# Altium schematic sheet pd.SchDoc(pd)
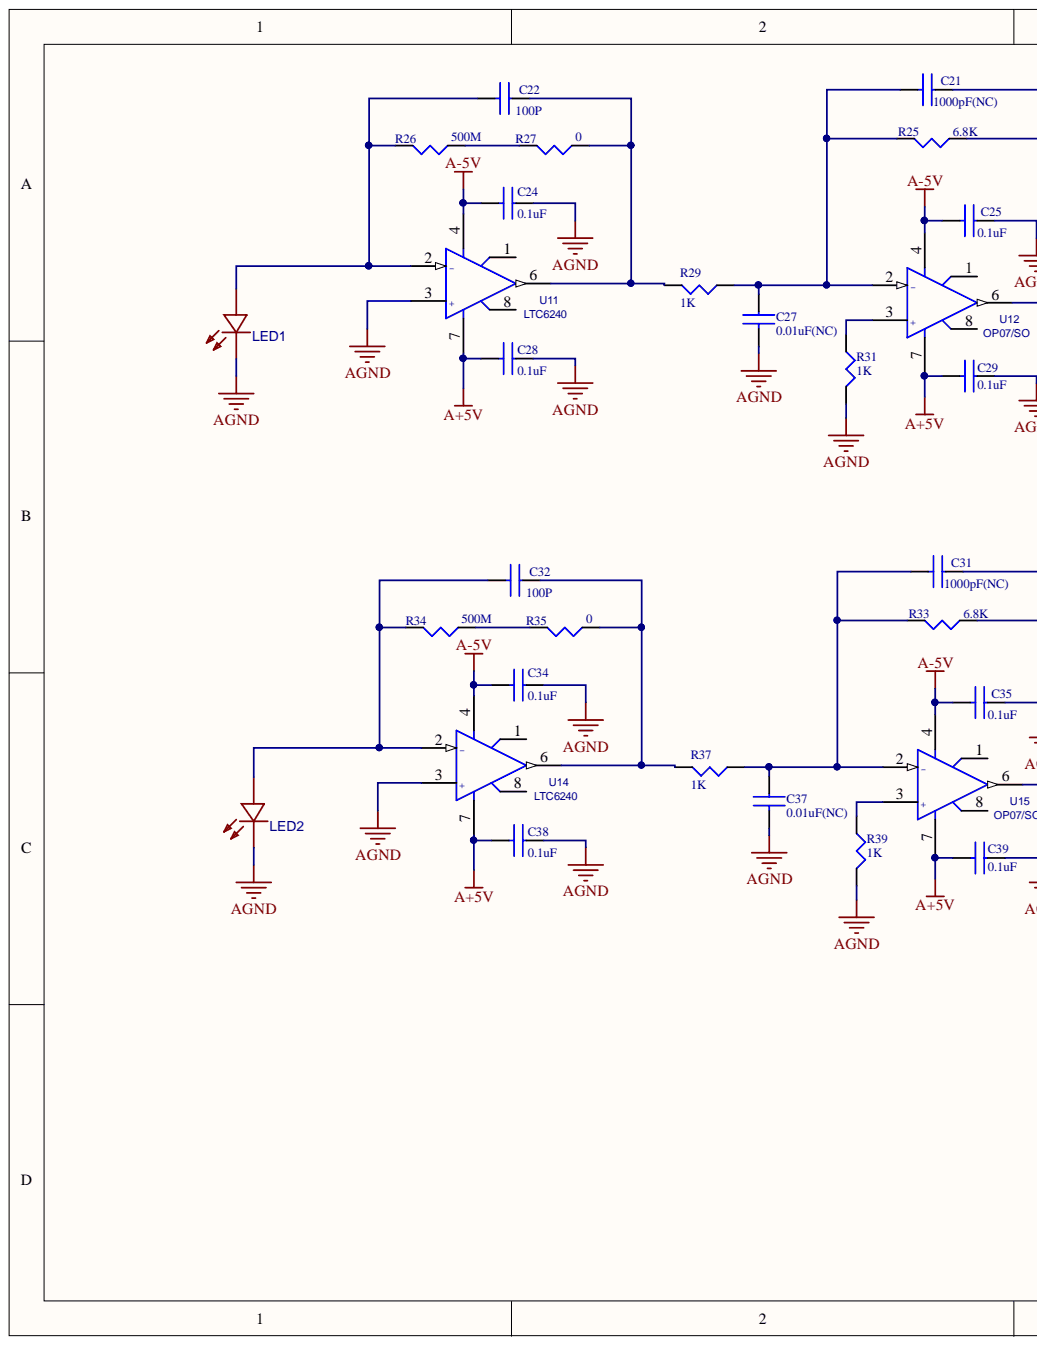
[diagram p0: pd.SchDoc, left]
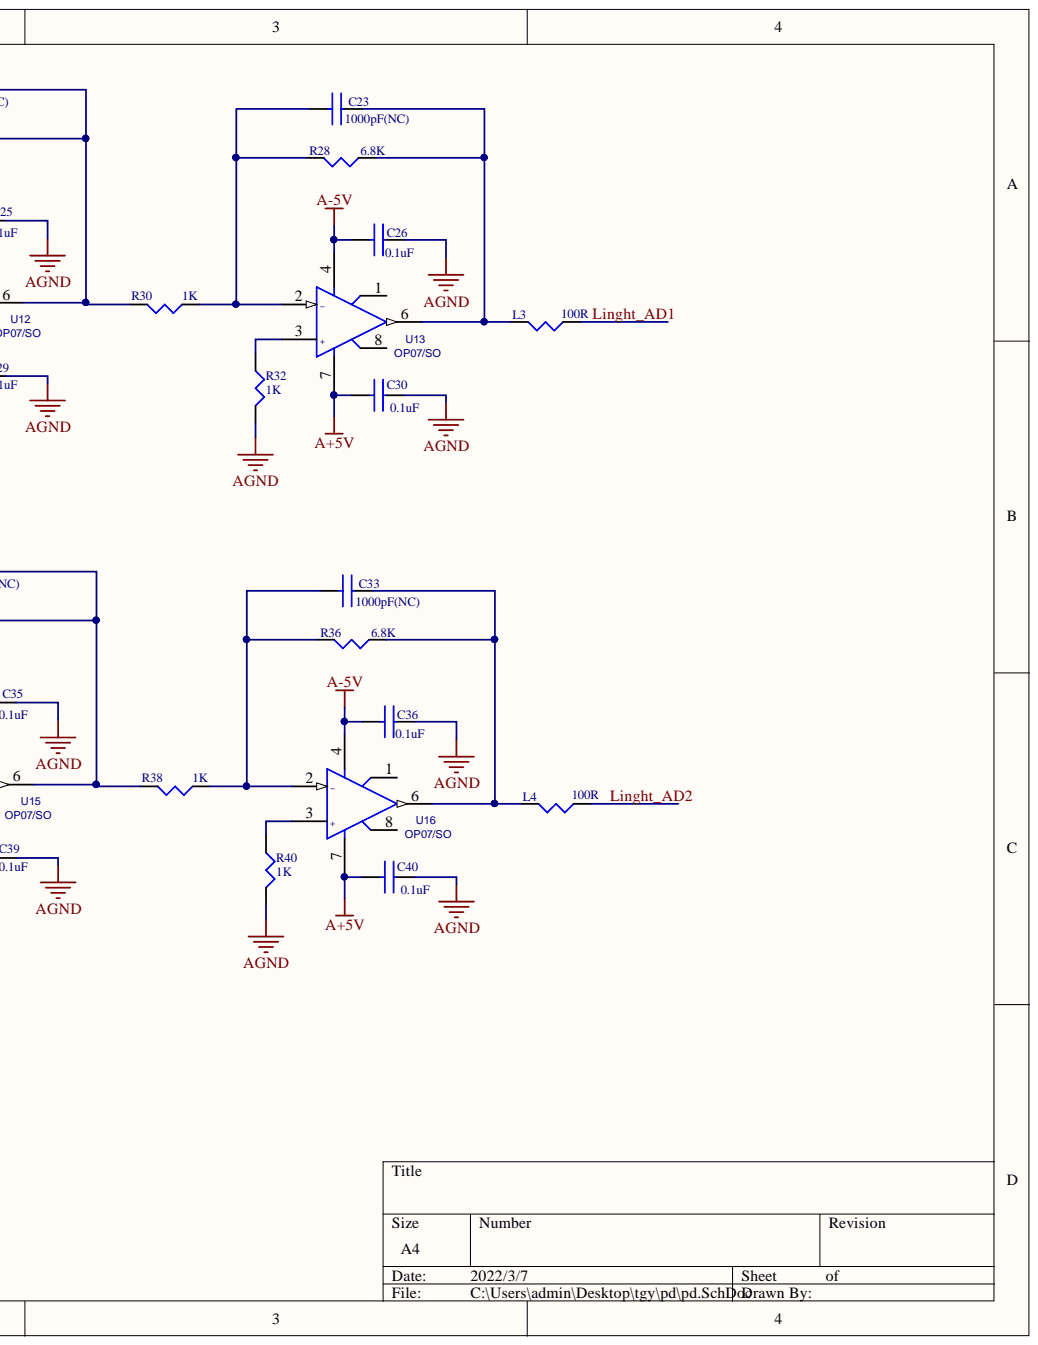
[diagram p1: pd.SchDoc, right]

## Parts
C21  1000pF(NC)  [0603_C]
C22  100P  [0603_C]
C23  1000pF(NC)  [0603_C]
C24  0.1uF  [0603_C]
C25  0.1uF  [0603_C]
C26  0.1uF  [0603_C]
C27  0.01uF(NC)  [0603_C]
C28  0.1uF  [0603_C]
C29  0.1uF  [0603_C]
C30  0.1uF  [0603_C]
C31  1000pF(NC)  [0603_C]
C32  100P  [0603_C]
C33  1000pF(NC)  [0603_C]
C34  0.1uF  [0603_C]
C35  0.1uF  [0603_C]
C36  0.1uF  [0603_C]
C37  0.01uF(NC)  [0603_C]
C38  0.1uF  [0603_C]
C39  0.1uF  [0603_C]
C40  0.1uF  [0603_C]
L3  100R  [R0603]
L4  100R  [R0603]
LED1  LTST-C191TGKT  [PD]  (value not drawn)
LED2  LTST-C191TGKT  [PD]  (value not drawn)
R25  6.8K  [0603_R]
R26  500M  [0603_R]
R27  0  [0603_R]
R28  6.8K  [0603_R]
R29  1K  [0603_R]
R30  1K  [0603_R]
R31  1K  [0603_R]
R32  1K  [0603_R]
R33  6.8K  [0603_R]
R34  500M  [0603_R]
R35  0  [0603_R]
R36  6.8K  [0603_R]
R37  1K  [0603_R]
R38  1K  [0603_R]
R39  1K  [0603_R]
R40  1K  [0603_R]
U11  LTC6240  [TSOT-23-5]
U12  OP07/SO  [TSOT-23-5]
U13  OP07/SO  [TSOT-23-5]
U14  LTC6240  [TSOT-23-5]
U15  OP07/SO  [TSOT-23-5]
U16  OP07/SO  [TSOT-23-5]

## Nets
A+5V: C28.2 C29.2 C30.2 C38.2 C39.2 C40.2 U11.7 U12.7 U13.7 U14.7 U15.7 U16.7
A-5V: C24.2 C25.2 C26.2 C34.2 C35.2 C36.2 U11.4 U12.4 U13.4 U14.4 U15.4 U16.4
AGND: C24.1 C25.1 C26.1 C27.2 C28.1 C29.1 C30.1 C34.1 C35.1 C36.1 C37.2 C38.1 C39.1 C40.1 LED1.1 LED2.1 R31.2 R32.2 R39.2 R40.2 U11.3(+) U14.3(+)
Linght_AD1: L3.1
Linght_AD2: L4.1
NetC21_1: C21.1 R25.1 R30.2 U12.6
NetC21_2: C21.2 C27.1 R25.2 R29.1 U12.2(-)
NetC22_1: C22.1 R27.1 R29.2 U11.6
NetC22_2: C22.2 LED1.2 R26.2 U11.2(-)
NetC23_1: C23.1 L3.2 R28.1 U13.6
NetC23_2: C23.2 R28.2 R30.1 U13.2(-)
NetC31_1: C31.1 R33.1 R38.2 U15.6
NetC31_2: C31.2 C37.1 R33.2 R37.1 U15.2(-)
NetC32_1: C32.1 R35.1 R37.2 U14.6
NetC32_2: C32.2 LED2.2 R34.2 U14.2(-)
NetC33_1: C33.1 L4.2 R36.1 U16.6
NetC33_2: C33.2 R36.2 R38.1 U16.2(-)
NetR26_1: R26.1 R27.2
NetR31_1: R31.1 U12.3(+)
NetR32_1: R32.1 U13.3(+)
NetR34_1: R34.1 R35.2
NetR39_1: R39.1 U15.3(+)
NetR40_1: R40.1 U16.3(+)

Not connected: U11 1 8; U12 1 8; U13 1 8; U14 1 8; U15 1 8; U16 1 8

## Library data of the parts
C21-C40 = CAP NP 0805: Component Kind: Standard; Library Name: C:\Users\Lenovo\Desktop\定量PCR\电路图\PD板\PD.PcbLib
L3 L4 R25-R40 = RES 0805: Component Kind: Standard; Library Name: C-LifeReal_1.SchLib
LED1 LED2 = LTST-C191TGKT: BOM_Manufacturer: LITEON; Contributor: LCSC; spiceSymbolName: LTST-C191TGKT; spicePre: L; Component Kind: Standard; BOM_Supplier Part: C125101; BOM_Supplier: LCSC; BOM_Manufacturer Part: LTST-C191TGKT
U11-U16 = OP07/SO_1: Component Kind: Standard; Library Name: C-LifeReal_1.SchLib
